# Altium schematic sheet Robot_Motor_Controller.SchDoc
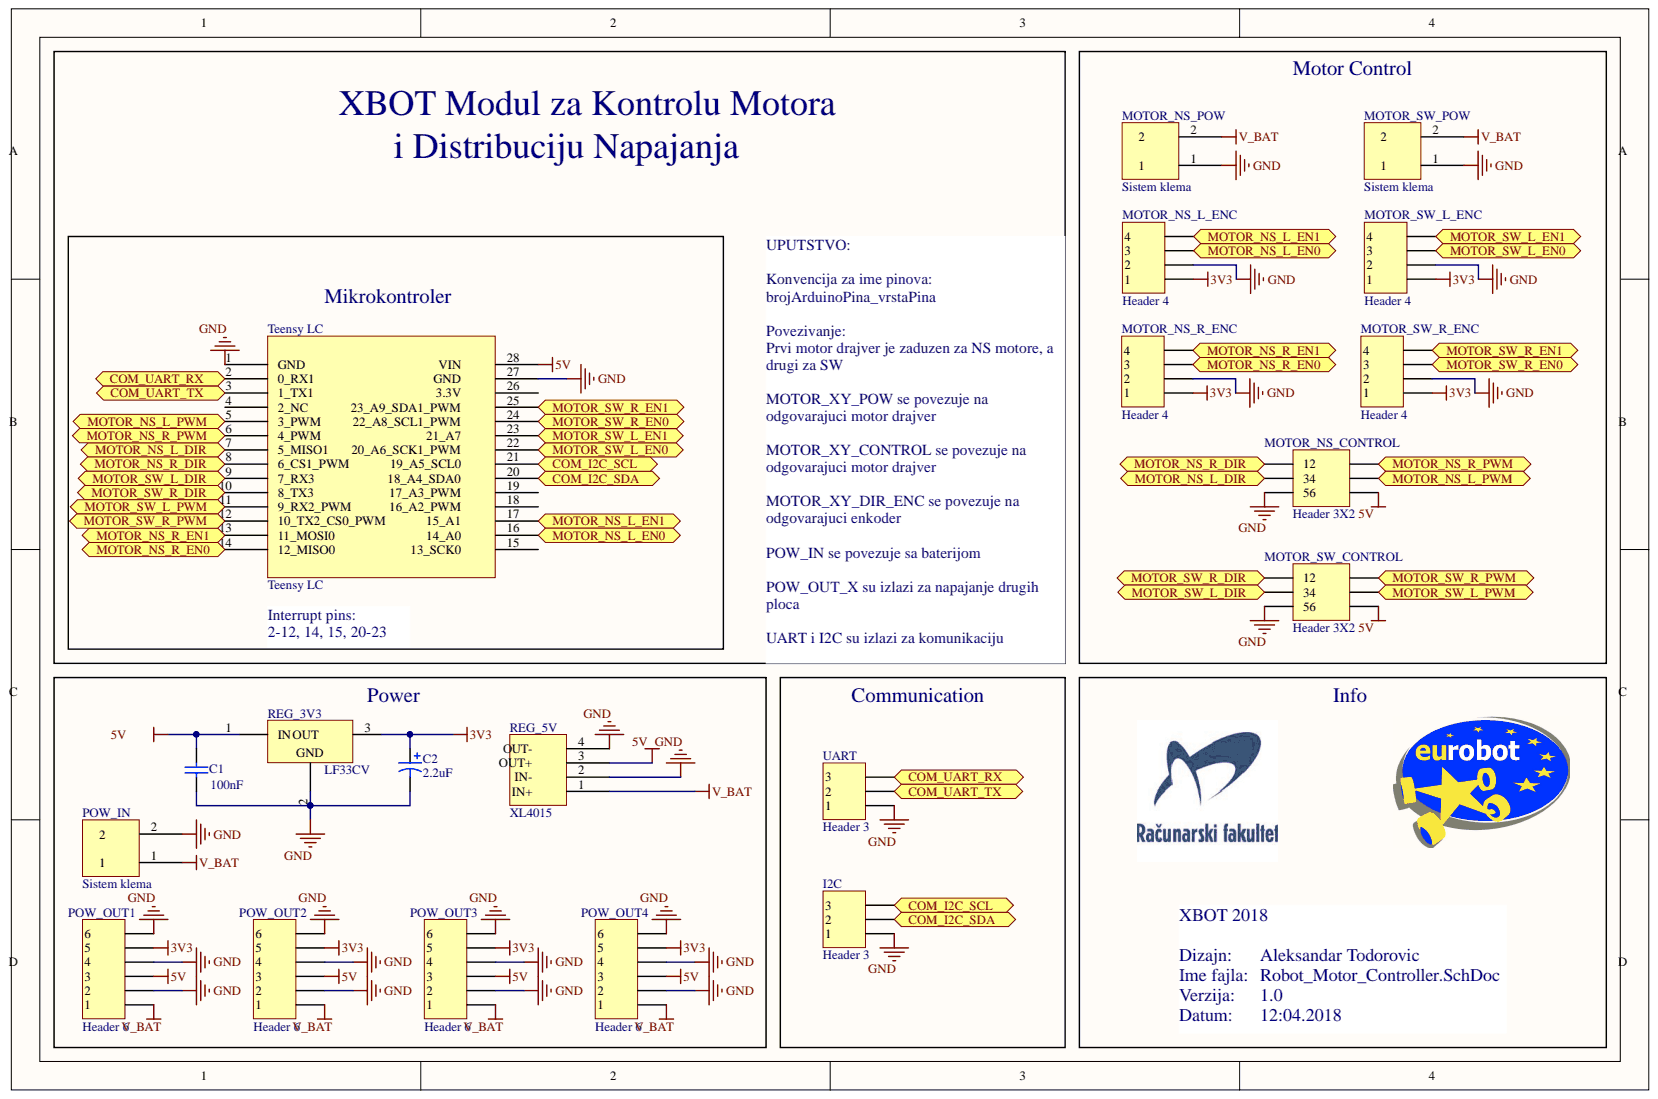
[diagram p0: Robot_Motor_Controller.SchDoc]

## Parts
C1  value 100nF  [C1206]  (hidden comment: Cap Semi)
C2  value 2.2uF  [D]  (hidden comment: 94SC156X0025DBP)
I2C  Header 3  [HDR1X3]
MOTOR_NS_CONTROL  Header 3X2  [HDR2X3]
MOTOR_NS_L_ENC  Header 4  [HDR1X4]
MOTOR_NS_POW  Sistem klema  [Sistem klema]
MOTOR_NS_R_ENC  Header 4  [HDR1X4]
MOTOR_SW_CONTROL  Header 3X2  [HDR2X3]
MOTOR_SW_L_ENC  Header 4  [HDR1X4]
MOTOR_SW_POW  Sistem klema  [Sistem klema]
MOTOR_SW_R_ENC  Header 4  [HDR1X4]
POW_IN  Sistem klema  [Sistem klema]
POW_OUT1  Header 6  [HDR1X6]
POW_OUT2  Header 6  [HDR1X6]
POW_OUT3  Header 6  [HDR1X6]
POW_OUT4  Header 6  [HDR1X6]
REG_3V3  LF33CV  [TO-220A]
REG_5V  XL4015  [XL4015]
Teensy_LC  Teensy LC  [Teensy LC]
UART  Header 3  [HDR1X3]

## Ports
COM_I2C_SCL, COM_I2C_SDA, COM_UART_RX, COM_UART_TX, MOTOR_NS_L_DIR, MOTOR_NS_L_EN0, MOTOR_NS_L_EN1, MOTOR_NS_L_PWM, MOTOR_NS_R_DIR, MOTOR_NS_R_EN0, MOTOR_NS_R_EN1, MOTOR_NS_R_PWM, MOTOR_SW_L_DIR, MOTOR_SW_L_EN0, MOTOR_SW_L_EN1, MOTOR_SW_L_PWM, MOTOR_SW_R_DIR, MOTOR_SW_R_EN0, MOTOR_SW_R_EN1, MOTOR_SW_R_PWM

## Nets
3V3: C2.1 MOTOR_NS_L_ENC.1 MOTOR_NS_R_ENC.1 MOTOR_SW_L_ENC.1 MOTOR_SW_R_ENC.1 POW_OUT1.5 POW_OUT2.5 POW_OUT3.5 POW_OUT4.5 REG_3V3.3
5V: C1.2 MOTOR_NS_CONTROL.6 MOTOR_SW_CONTROL.6 POW_OUT1.3 POW_OUT2.3 POW_OUT3.3 POW_OUT4.3 REG_3V3.1 REG_5V.3 Teensy_LC.28
GND: C1.1 C2.2 I2C.1 MOTOR_NS_CONTROL.5 MOTOR_NS_L_ENC.2 MOTOR_NS_POW.1 MOTOR_NS_R_ENC.2 MOTOR_SW_CONTROL.5 MOTOR_SW_L_ENC.2 MOTOR_SW_POW.1 MOTOR_SW_R_ENC.2 POW_IN.2 POW_OUT1.2 POW_OUT1.4 POW_OUT1.6 POW_OUT2.2 POW_OUT2.4 POW_OUT2.6 POW_OUT3.2 POW_OUT3.4 POW_OUT3.6 POW_OUT4.2 POW_OUT4.4 POW_OUT4.6 REG_3V3.2 REG_5V.2 REG_5V.4 Teensy_LC.1(GND) Teensy_LC.27 UART.1
V_BAT: MOTOR_NS_POW.2 MOTOR_SW_POW.2 POW_IN.1 POW_OUT1.1 POW_OUT2.1 POW_OUT3.1 POW_OUT4.1 REG_5V.1
COM_I2C_SCL: I2C.3 Teensy_LC.21 ; port COM_I2C_SCL
COM_I2C_SDA: I2C.2 Teensy_LC.20 ; port COM_I2C_SDA
COM_UART_RX: Teensy_LC.2(0_RX1) UART.3 ; port COM_UART_RX
COM_UART_TX: Teensy_LC.3(1_TX1) UART.2 ; port COM_UART_TX
MOTOR_NS_L_DIR: MOTOR_NS_CONTROL.3 Teensy_LC.7(5_MISO1) ; port MOTOR_NS_L_DIR
MOTOR_NS_L_EN0: MOTOR_NS_L_ENC.3 Teensy_LC.16 ; port MOTOR_NS_L_EN0
MOTOR_NS_L_EN1: MOTOR_NS_L_ENC.4 Teensy_LC.17 ; port MOTOR_NS_L_EN1
MOTOR_NS_L_PWM: MOTOR_NS_CONTROL.4 Teensy_LC.5(3_PWM) ; port MOTOR_NS_L_PWM
MOTOR_NS_R_DIR: MOTOR_NS_CONTROL.1 Teensy_LC.8(6_CS1_PWM) ; port MOTOR_NS_R_DIR
MOTOR_NS_R_EN0: MOTOR_NS_R_ENC.3 Teensy_LC.14(12_MISO0) ; port MOTOR_NS_R_EN0
MOTOR_NS_R_EN1: MOTOR_NS_R_ENC.4 Teensy_LC.13(11_MOSI0) ; port MOTOR_NS_R_EN1
MOTOR_NS_R_PWM: MOTOR_NS_CONTROL.2 Teensy_LC.6(4_PWM) ; port MOTOR_NS_R_PWM
MOTOR_SW_L_DIR: MOTOR_SW_CONTROL.3 Teensy_LC.9(7_RX3) ; port MOTOR_SW_L_DIR
MOTOR_SW_L_EN0: MOTOR_SW_L_ENC.3 Teensy_LC.22 ; port MOTOR_SW_L_EN0
MOTOR_SW_L_EN1: MOTOR_SW_L_ENC.4 Teensy_LC.23 ; port MOTOR_SW_L_EN1
MOTOR_SW_L_PWM: MOTOR_SW_CONTROL.4 Teensy_LC.11(9_RX2_PWM) ; port MOTOR_SW_L_PWM
MOTOR_SW_R_DIR: MOTOR_SW_CONTROL.1 Teensy_LC.10(8_TX3) ; port MOTOR_SW_R_DIR
MOTOR_SW_R_EN0: MOTOR_SW_R_ENC.3 Teensy_LC.24 ; port MOTOR_SW_R_EN0
MOTOR_SW_R_EN1: MOTOR_SW_R_ENC.4 Teensy_LC.25 ; port MOTOR_SW_R_EN1
MOTOR_SW_R_PWM: MOTOR_SW_CONTROL.2 Teensy_LC.12(10_TX2_CS0_PWM) ; port MOTOR_SW_R_PWM

Not connected: Teensy_LC 4(2_NC) 15 18 19 26

## Library data of the parts
C1 = Cap Semi: Capacitor (Semiconductor SIM Model); Component Kind: Standard; LatestRevisionDate: 29-May-2009; LatestRevisionNote: IPC-7351 Footprint Added.; Library Name: Miscellaneous Devices.SchLib; PackageDescription: Chip Capacitor; PackageReference: C1206; Published: 8-Jun-2000; Signal Integrity: Cap Semi; Simulation: CAP; Publisher: Altium Limited
C2 = 94SC156X0025DBP: Solid Aluminum Capacitor with Organic Semiconductor Electrolyte: 15uF, 25V, Bulk Pack; DrillSize: Nominal, Rounded to the nearest 0.1mm; Note: Part Numbers shown are for ± 20% capacitance tolerance (X0).; PCB_Layout: Complies with Manufacturer's Recommendation.; Component Kind: Standard; ComponentLink1Description: Manufacturer Link; ComponentLink1URL: http://www.vishay.com/; ComponentLink2Description: Datasheet; ComponentLink2URL: http://www.vishay.com/docs/90004/94sc.pdf; DatasheetDocument: 23-May-2001; Library Name: Vishay Electrolytic Radial-Lead Capacitor.IntLib; PackageReference: D; Published: 19-Mar-2004; Signal Integrity: 94SC156X0025DBP; Simulation: CAP; Publisher: Altium Limited
I2C UART = Header 3: Header, 3-Pin; Component Kind: Standard; LatestRevisionDate: 17-Jul-2002; LatestRevisionNote: Re-released for DXP Platform.; Library Name: Miscellaneous Connectors.IntLib; Signal Integrity: Connector; Publisher: Altium Limited
MOTOR_NS_CONTROL MOTOR_SW_CONTROL = Header 3X2: Header, 3-Pin, Dual row; Component Kind: Standard; LatestRevisionDate: 17-Jul-2002; LatestRevisionNote: Re-released for DXP Platform.; Library Name: Miscellaneous Connectors.IntLib; Signal Integrity: Connector; Publisher: Altium Limited
MOTOR_NS_L_ENC MOTOR_NS_R_ENC MOTOR_SW_L_ENC MOTOR_SW_R_ENC = Header 4: Header, 4-Pin; Component Kind: Standard; LatestRevisionDate: 17-Jul-2002; LatestRevisionNote: Re-released for DXP Platform.; Library Name: Miscellaneous Connectors.IntLib; Signal Integrity: Connector; Publisher: Altium Limited
POW_OUT1-POW_OUT4 = Header 6: Header, 6-Pin; Component Kind: Standard; LatestRevisionDate: 17-Jul-2002; LatestRevisionNote: Re-released for DXP Platform.; Library Name: Miscellaneous Connectors.IntLib; Signal Integrity: Connector; Publisher: Altium Limited
REG_3V3 = LF33CV: 500mA, Very Low Drop (0.45V) Voltage Regulator; Component Kind: Standard; ComponentLink1Description: Manufacturer Link; ComponentLink1URL: http://www.st.com/; ComponentLink2Description: Datasheet; ComponentLink2URL: http://www.st.com/stonline/books/pdf/docs/2574.pdf; DatasheetVersion: Feb-2004; LatestRevisionDate: 06-Jun-2005; LatestRevisionNote: PCB Pin sequence re-ordered.; Library Name: ST Power Mgt Voltage Regulator.IntLib; PackageDescription: TO, Thru-Hole, Vertical, Heatsink Mounted; 3 In-Line Leads; Pitch 2.54 mm; PackageReference: TO-220A; PackageVersion: Jul-2004; Published: 24-Aug-2001; Publisher: Altium Limited
MOTOR_NS_POW MOTOR_SW_POW POW_IN = Sistem klema: Component Kind: Standard; Library Name: LaserParts.SchLib
REG_5V = XL4015: Component Kind: Standard; Library Name: XL4015.SchLib
Teensy_LC = Teensy LC: Component Kind: Standard; Library Name: Teensy_LC.SchLib
